# Altium schematic sheet Micro_Grid_Sch.SchDoc
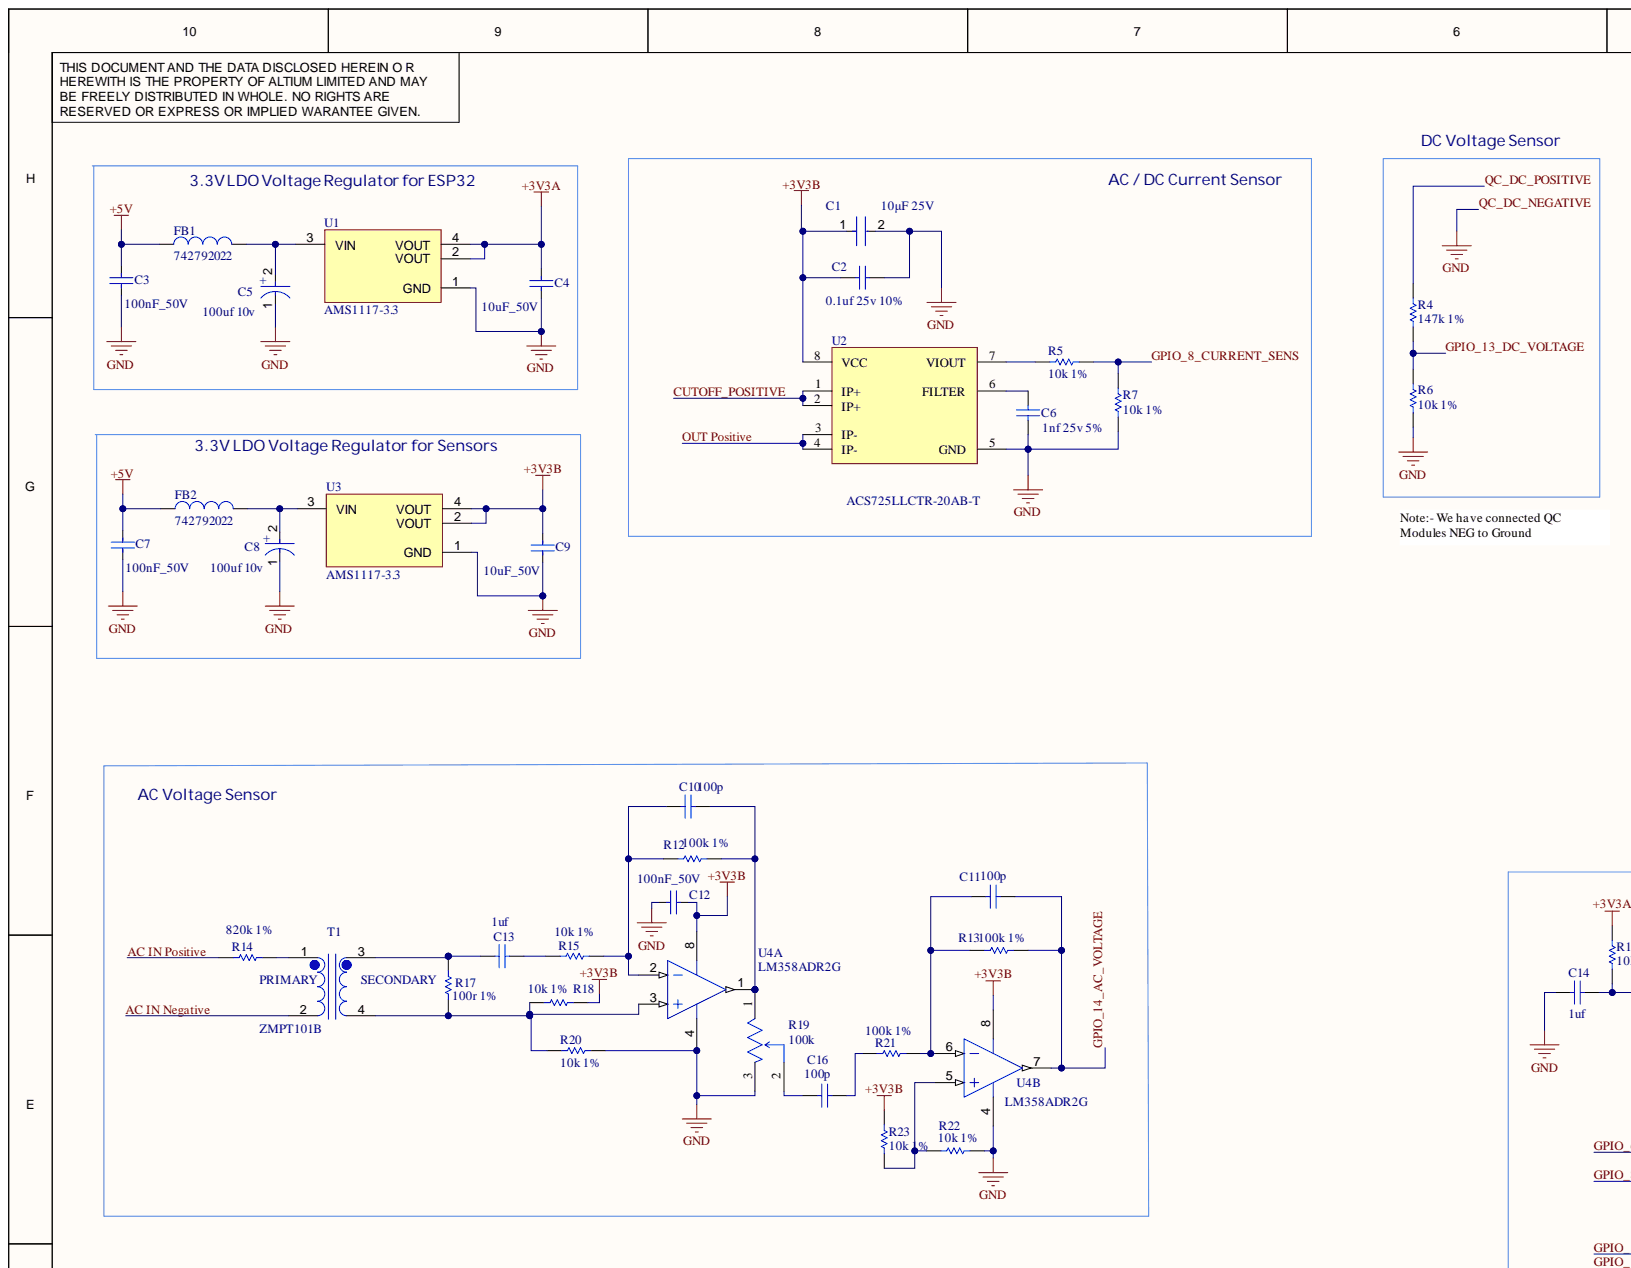
[diagram p0: Micro_Grid_Sch.SchDoc, top left]
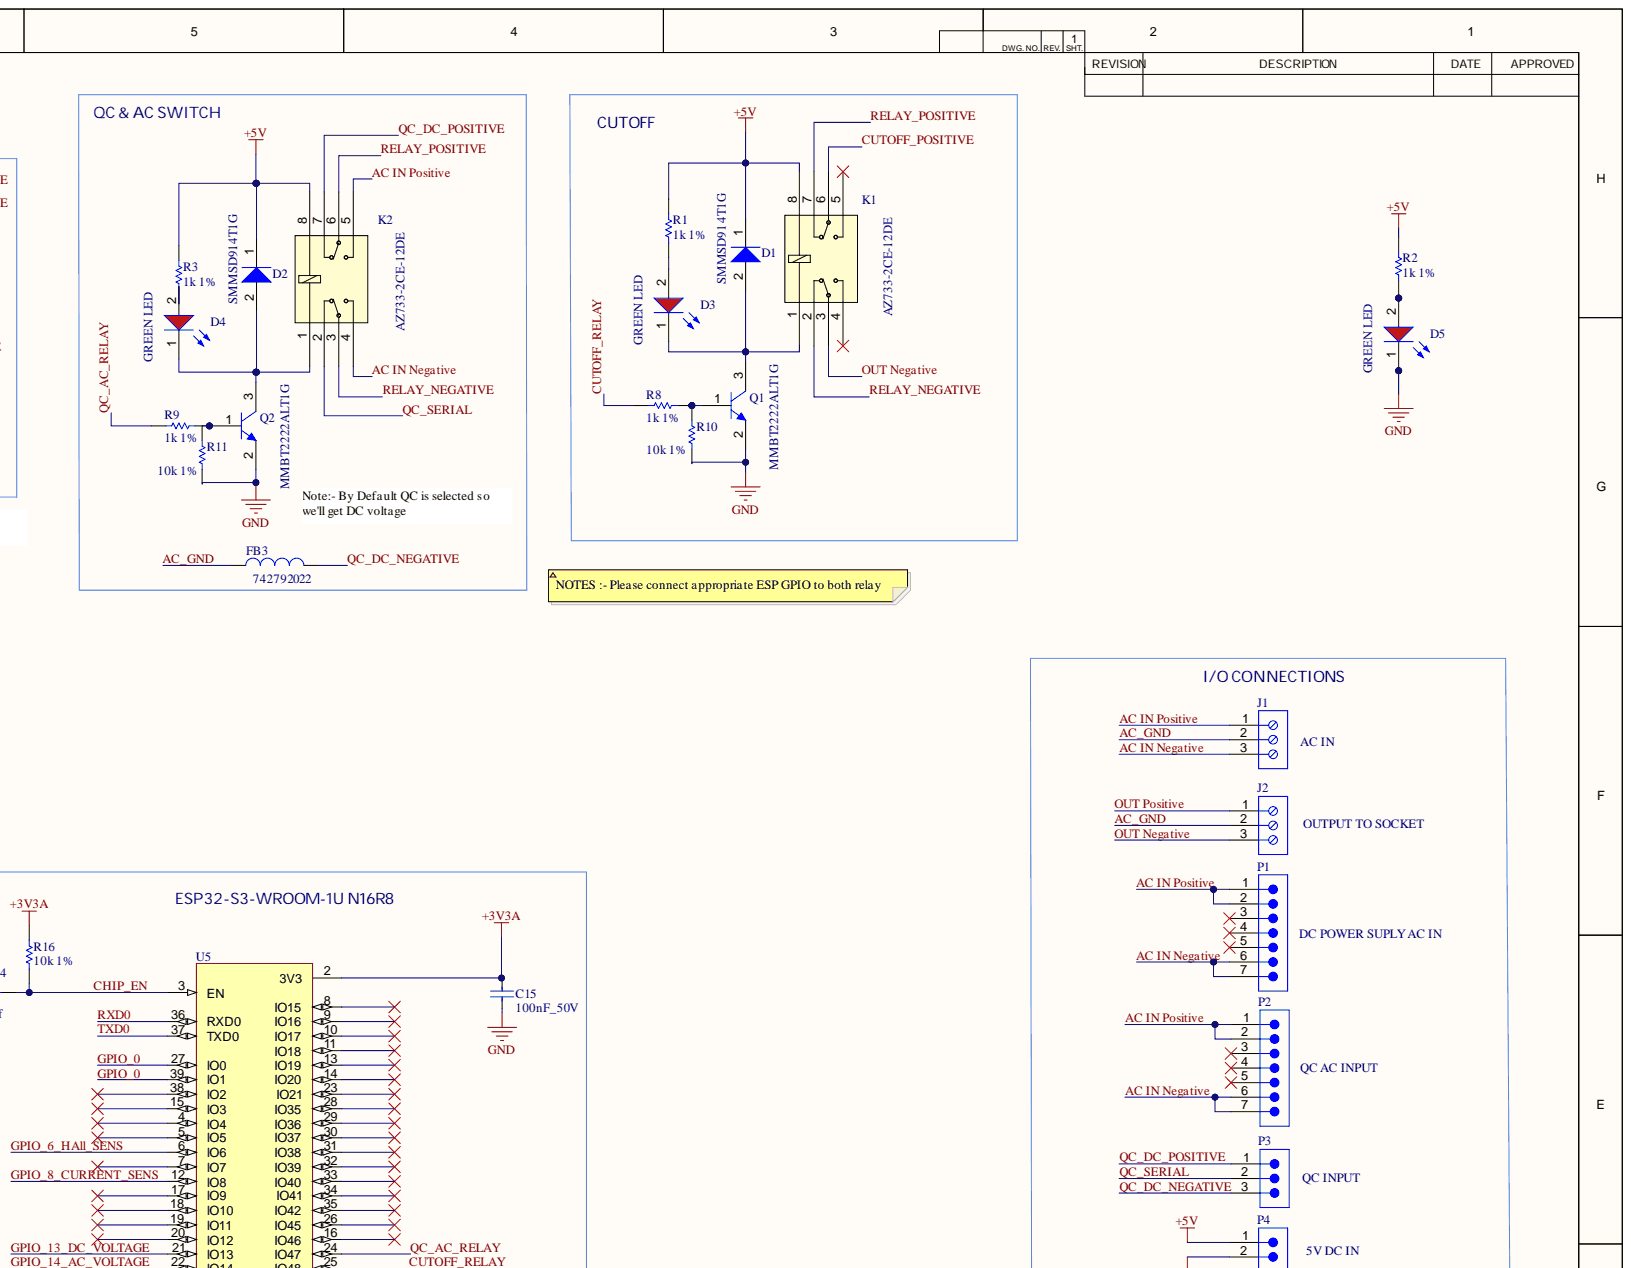
[diagram p1: Micro_Grid_Sch.SchDoc, top right]
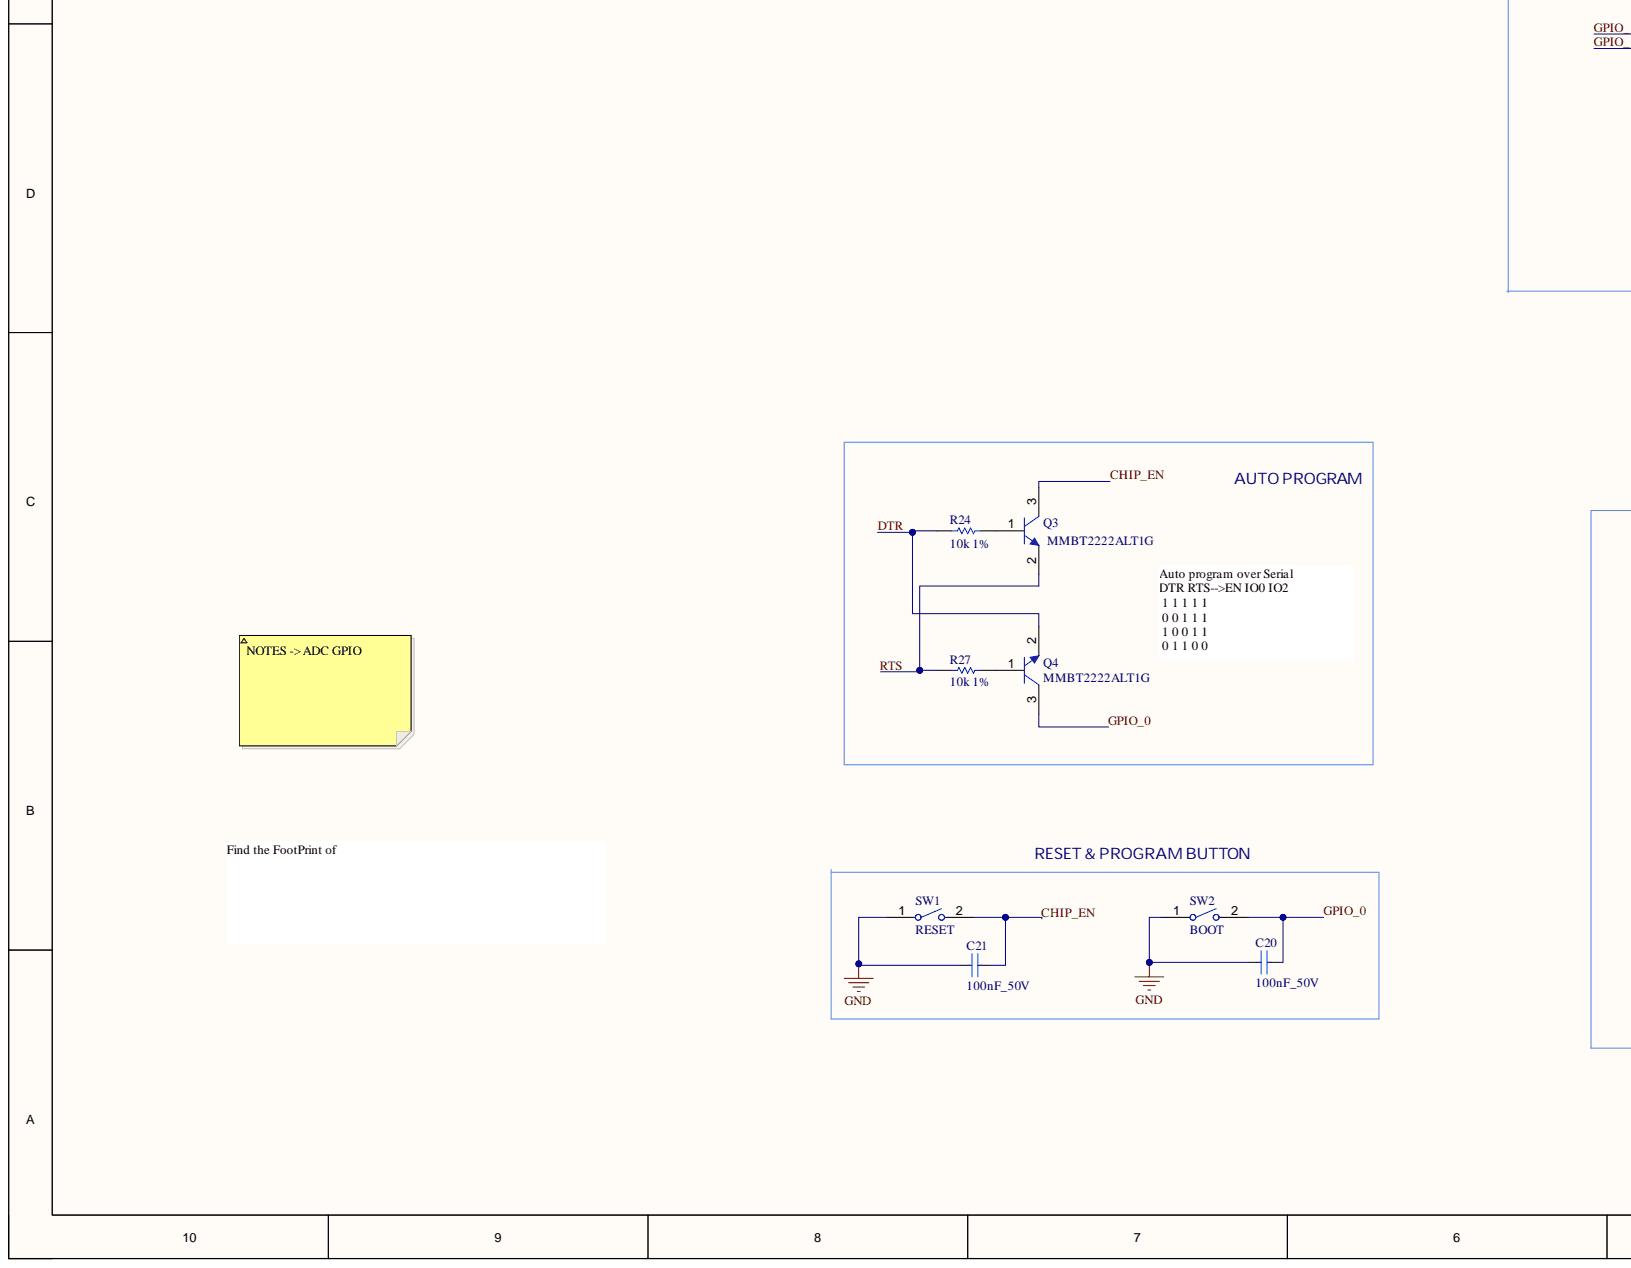
[diagram p2: Micro_Grid_Sch.SchDoc, bottom left]
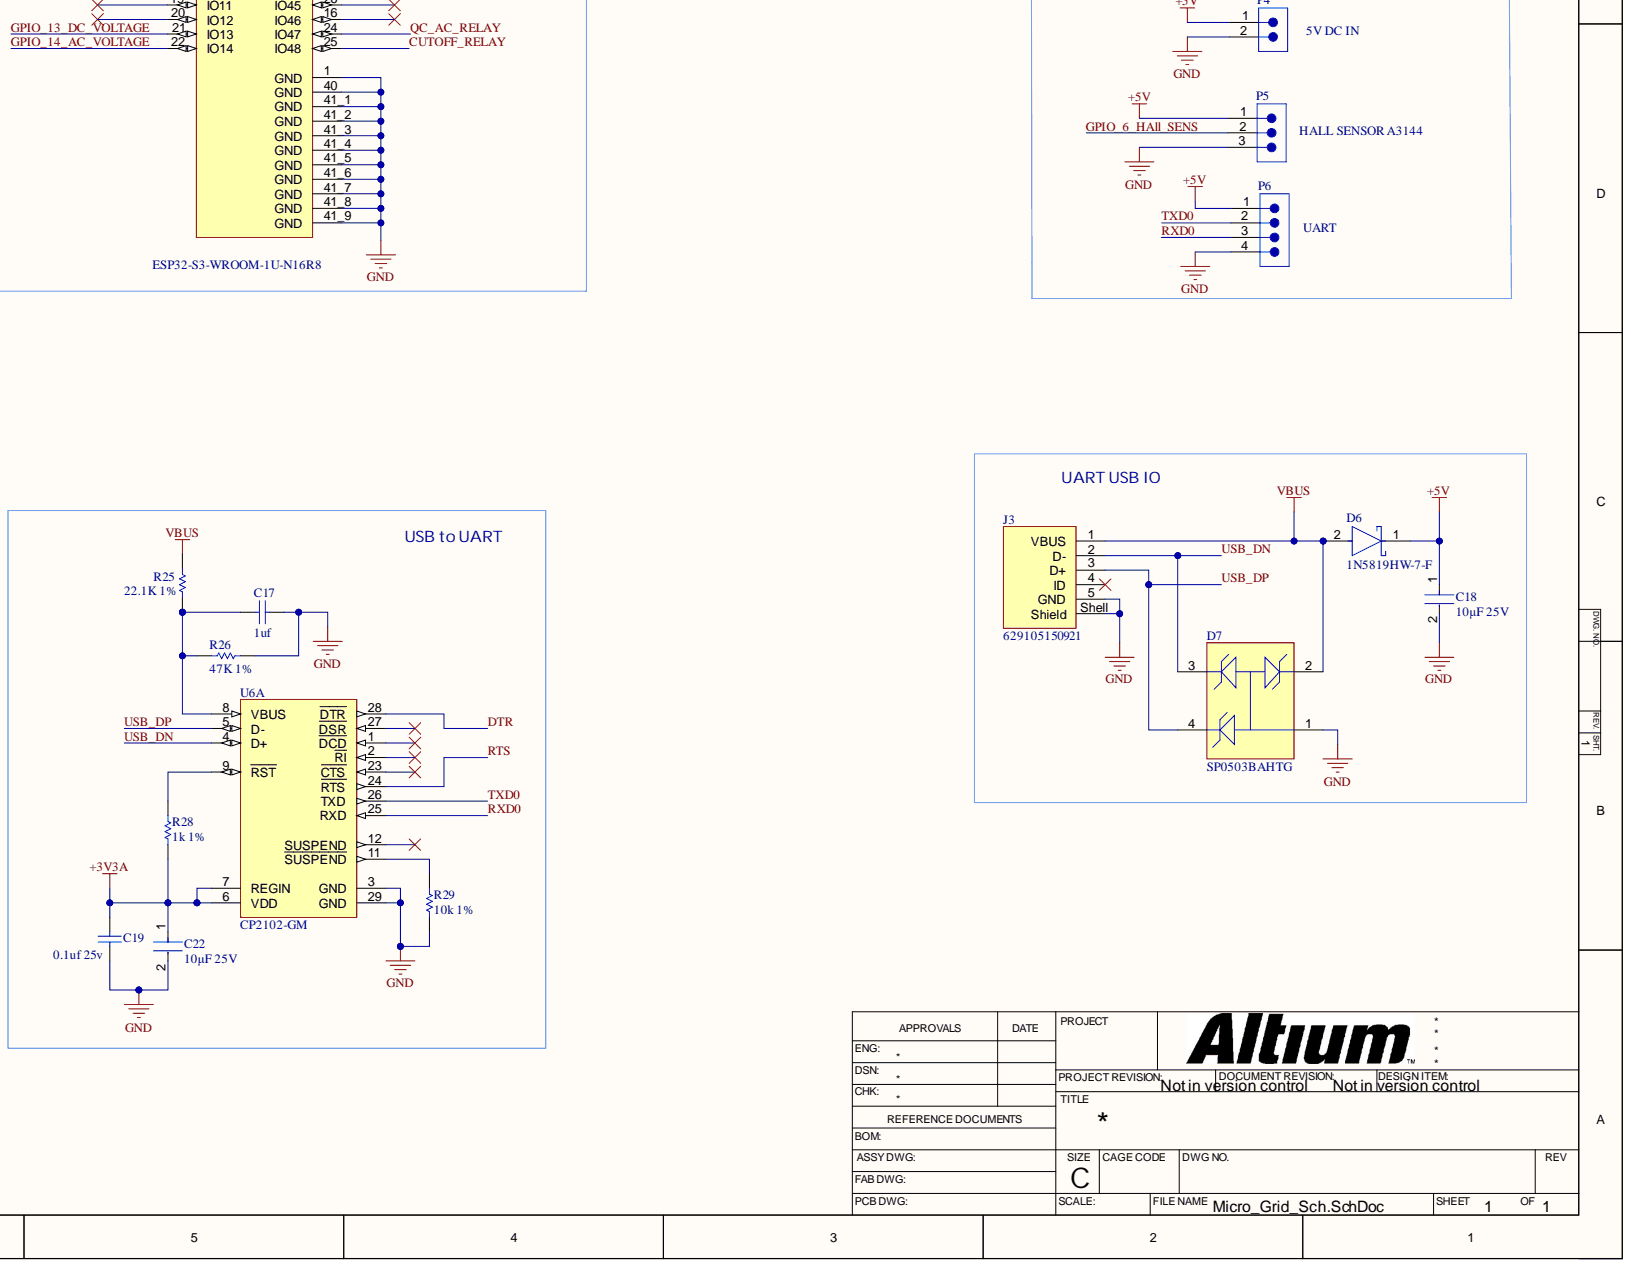
[diagram p3: Micro_Grid_Sch.SchDoc, bottom right]

## Parts
C1  10μF 25V  Voltage: 25V  [FP-C2012-125-0_2-IPC_B]
C2  0.1uf 25v 10%  [CAPC2013X135X50ML15T20]
C3  value 100nF_50V  [C0805]  (hidden comment: C0805C104K5RAC7411)
C4  value 10uF_50V  [C0805]  (hidden comment: C0805C104K5RAC7411)
C5  100uf 10v  [FP-SC-MFG]
C6  1nf 25v 5%  [CAPC2013X145X50NL20T20]
C7  value 100nF_50V  [C0805]  (hidden comment: C0805C104K5RAC7411)
C8  100uf 10v  [FP-SC-MFG]
C9  value 10uF_50V  [C0805]  (hidden comment: C0805C104K5RAC7411)
C10  100p  [CAPC2013X140X50NL20T25]
C11  100p  [CAPC2013X140X50NL20T25]
C12  value 100nF_50V  [C0805]  (hidden comment: C0805C104K5RAC7411)
C13  1uf  [CAPC2013X140X50LL20T25]
C14  1uf  [CAPC2013X140X50LL20T25]
C15  value 100nF_50V  [C0805]  (hidden comment: C0805C104K5RAC7411)
C16  100p  [CAPC2013X140X50NL20T25]
C17  1uf  [CAPC2013X140X50LL20T25]
C18  10μF 25V  Voltage: 25V  [FP-C2012-125-0_2-IPC_B]
C19  0.1uf 25v  [CAPC2013X135X50ML15T20]
C20  value 100nF_50V  [C0805]  (hidden comment: C0805C104K5RAC7411)
C21  value 100nF_50V  [C0805]  (hidden comment: C0805C104K5RAC7411)
C22  10μF 25V  Voltage: 25V  [FP-C2012-125-0_2-IPC_B]
D1  SMMSD914T1G  [ONSC-SOD-123-2-425-04_V]
D2  SMMSD914T1G  [ONSC-SOD-123-2-425-04_V]
D3  GREEN LED  [WL-SMCW_0805_150080xx75000]
D4  GREEN LED  [WL-SMCW_0805_150080xx75000]
D5  GREEN LED  [WL-SMCW_0805_150080xx75000]
D6  1N5819HW-7-F  [FP-SOD123-IPC_A]
D7  SP0503BAHTG  [LFUS-SOT143-4_V]
FB1  742792022  [WE-CBF_0805_L2W1.2H0.9_HC]
FB2  742792022  [WE-CBF_0805_L2W1.2H0.9_HC]
FB3  742792022  [WE-CBF_0805_L2W1.2H0.9_HC]
J1  AC IN  [691214110003]
J2  OUTPUT TO SOCKET  [691214110003]
J3  629105150921  Connector Type: USB  [629105150921]
K1  AZ733-2CE-12DE  [AZ733-2C_AMZ]
K2  AZ733-2CE-12DE  [AZ733-2C_AMZ]
P1  DC POWER SUPLY AC IN  [61300711121]
P2  QC AC INPUT  [61300711121]
P3  QC INPUT  [61300311121]
P4  5V DC IN  [61300211121]
P5  HALL SENSOR A3144  [61300311121]
P6  UART  [61900411121]
Q1  MMBT2222ALT1G  [ONSC-SOT-23-3-318-08_V]
Q2  MMBT2222ALT1G  [ONSC-SOT-23-3-318-08_V]
Q3  MMBT2222ALT1G  [ONSC-SOT-23-3-318-08_V]
Q4  MMBT2222ALT1G  [ONSC-SOT-23-3-318-08_V]
R1  1k 1%  Tolerance: 1%  [RESC2013X60X35ML10T20]
R2  1k 1%  Tolerance: 1%  [RESC2013X60X35ML10T20]
R3  1k 1%  [RESC2013X60X35ML10T20]
R4  147k 1%  [RESC2013X70X40LL20T20]
R5  10k 1%  [RESC2013X50X30NL20T20]
R6  10k 1%  [RESC2013X60X40NL15T20]
R7  10k 1%  [RESC2013X50X30NL20T20]
R8  1k 1%  Tolerance: 1%  [RESC2013X60X35ML10T20]
R9  1k 1%  Tolerance: 1%  [RESC2013X60X35ML10T20]
R10  10k 1%  [RESC2013X60X40NL15T20]
R11  10k 1%  [RESC2013X60X40NL15T20]
R12  100k 1%  [RESC2013X60X30NL20T20]
R13  100k 1%  [RESC2013X60X30NL20T20]
R14  820k 1%  [RESC2013X70X40ML20T20]
R15  10k 1%  [RESC2013X60X40NL15T20]
R16  10k 1%  [RESC2013X60X40NL15T20]
R17  100r 1%  [RESC2013X50X30LL20T20]
R18  10k 1%  [RESC2013X60X40NL15T20]
R19  100k  [FP-TS53YL-MFG]
R20  10k 1%  [RESC2013X60X40NL15T20]
R21  100k 1%  [RESC2013X60X30NL20T20]
R22  10k 1%  [RESC2013X60X40NL15T20]
R23  10k 1%  [RESC2013X60X40NL15T20]
R24  10k 1%  [RESC2013X60X40NL15T20]
R25  22.1K 1%  [RESC2013X70X40NL20T20]
R26  47K 1%  [RESC2013X60X35NL10T20]
R27  10k 1%  [RESC2013X60X40NL15T20]
R28  1k 1%  Tolerance: 1%  [RESC2013X60X35ML10T20]
R29  10k 1%  [RESC2013X60X35NL10T20]
SW1  RESET  [TECO-FSMSM-2_V]
SW2  BOOT  [TECO-FSMSM-2_V]
T1  ZMPT101B  [XFMR_ZMPT101B]
U1  AMS1117-3.3  [SOT-223]
U2  ACS725LLCTR-20AB-T  [FP-LCN-8-IPC_B]
U3  AMS1117-3.3  [SOT-223]
U4  LM358ADR2G  [ONSC-SOIC-8-751-07_V]  (parts A,B)
U5  ESP32-S3-WROOM-1U-N16R8  [XCVR_ESP32-S3-WROOM-1U-N16R8]
U6  CP2102-GM  [SLAB-QFN-28-3125x3125TP_N]  (part A)

## Nets
+3V3A: C4.1 C15.1 C19.1 C22.1 R16.1 R28.2 U1.2(VOUT) U1.4(VOUT) U5.2(3V3) U6.6(VDD) U6.7(REGIN)
+3V3B: C1.1 C2.1 C9.1 C12.2 R18.2 R23.2 U2.8(VCC) U3.2(VOUT) U3.4(VOUT) U4.8
+5V: C3.1 C7.1 C18.1 D1.1 D2.1 D6.1 FB1.1 FB2.1 K1.8 K2.8 P4.1 P5.1 P6.1 R1.1 R2.1 R3.1
VBUS: D6.2 D7.2 J3.1(VBUS) R25.2
AC IN Negative: J1.3 K2.4 P1.6 P1.7 P2.6 P2.7 T1.2
AC IN Positive: J1.1 K2.5 P1.1 P1.2 P2.1 P2.2 R14.1
AC_GND: FB3.1 J1.2 J2.2
CHIP_EN: C14.2 C21.1 Q3.3 R16.2 SW1.2 U5.3(EN)
CUTOFF_POSITIVE: K1.6 U2.1(IP+) U2.2(IP+)
CUTOFF_RELAY: R8.1 U5.25(IO48)
DTR: Q4.2 R24.2 U6.28(DTR)
GPIO_0: C20.1 Q4.3 SW2.2 U5.27(IO0) U5.39(IO1)
GPIO_6_HAll_SENS: P5.2 U5.6(IO6)
GPIO_8_CURRENT_SENS: R5.2 R7.2 U5.12(IO8)
GPIO_13_DC_VOLTAGE: R4.2 R6.1 U5.21(IO13)
GPIO_14_AC_VOLTAGE: C11.2 R13.2 U4.7 U5.22(IO14)
OUT Negative: J2.3 K1.3
OUT Positive: J2.1 U2.3(IP-) U2.4(IP-)
QC_AC_RELAY: R9.1 U5.24(IO47)
QC_DC_NEGATIVE: C1.2 C2.2 C3.2 C4.2 C5.1 C6.2 C7.2 C8.1 C9.2 C12.1 C14.1 C15.2 C17.2 C18.2 C19.2 C20.2 C21.2 C22.2 D5.1 D7.1 FB3.2 J3.5(GND) J3.Shell(Shield) P3.3 P4.2 P5.3 P6.4 Q1.2 Q2.2 R6.2 R7.1 R10.1 R11.1 R19.3 R20.2 R22.2 R26.2 R29.1 SW1.1 SW2.1 U1.1(GND) U2.5(GND) U3.1(GND) U4.4 U5.1(GND) U5.40(GND) U5.41_1(GND) U5.41_2(GND) U5.41_3(GND) U5.41_4(GND) U5.41_5(GND) U5.41_6(GND) U5.41_7(GND) U5.41_8(GND) U5.41_9(GND) U6.3(GND) U6.29(GND)
QC_DC_POSITIVE: K2.7 P3.1 R4.1
QC_SERIAL: K2.2 P3.2
RELAY_NEGATIVE: K1.2 K2.3
RELAY_POSITIVE: K1.7 K2.6
RTS: Q3.2 R27.2 U6.24(RTS)
RXD0: P6.3 U5.36(RXD0) U6.25(RXD)
TXD0: P6.2 U5.37(TXD0) U6.26(TXD)
USB_DN: D7.3 J3.2(D-) U6.4(D+)
USB_DP: D7.4 J3.3(D+) U6.5(D-)
NetC5_2: C5.2 FB1.2 U1.3(VIN)
NetC6_1: C6.1 U2.6(FILTER)
NetC8_2: C8.2 FB2.2 U3.3(VIN)
NetC10_1: C10.1 R12.1 R15.2 U4.2
NetC10_2: C10.2 R12.2 R19.1 U4.1
NetC11_1: C11.1 R13.1 R21.2 U4.6
NetC13_1: C13.1 R17.2 T1.3
NetC13_2: C13.2 R15.1
NetC16_1: C16.1 R19.2
NetC16_2: C16.2 R21.1
NetC17_1: C17.1 R25.1 R26.1 U6.8(VBUS)
NetD1_2: D1.2 D3.1 K1.1 Q1.3
NetD2_2: D2.2 D4.1 K2.1 Q2.3
NetD3_2: D3.2 R1.2
NetD4_2: D4.2 R3.2
NetD5_2: D5.2 R2.2
NetQ1_1: Q1.1 R8.2 R10.2
NetQ2_1: Q2.1 R9.2 R11.2
NetQ3_1: Q3.1 R24.1
NetQ4_1: Q4.1 R27.1
NetR5_1: R5.1 U2.7(VIOUT)
NetR14_2: R14.2 T1.1
NetR17_1: R17.1 R18.1 R20.1 T1.4 U4.3
NetR22_1: R22.1 R23.1 U4.5
NetR28_1: R28.1 U6.9(RST)
NetR29_2: R29.2 U6.11(SUSPEND)

Not connected: J3 4(ID); K1 4 5; P1 3 4 5; P2 3 4 5; U5 4(IO4) 5(IO5) 7(IO7) 8(IO15) 9(IO16) 10(IO17) 11(IO18) 13(IO19) 14(IO20) 15(IO3) 16(IO46) 17(IO9) 18(IO10) 19(IO11) 20(IO12) 23(IO21) 26(IO45) 28(IO35) 29(IO36) 30(IO37) 31(IO38) 32(IO39) 33(IO40) 34(IO41) 35(IO42) 38(IO2); U6 1(DCD) 2(RI) 12(SUSPEND) 23(CTS) 27(DSR)

## Library data of the parts
C1 C18 C22 = CMP-08246-002675-1: Multilayer Ceramic Capacitors 10μF ±10% 25V X5R SMD 0805; Capacitance: 10uF; Case Code (Imperial): 0805; Case Code (Metric): 2012; Case/Package: 0805; Component Kind: Standard; Depth: 1.25mm; Dielectric: X5R; Dielectric Material: Ceramic; Height: 1.25mm; Lead Free: Lead Free; Length: 2mm; Library Name: Altium Content Vault; Max Operating Temperature: 85°C; Min Operating Temperature: -55°C; Mount: Surface Mount; Package Quantity: 1; Packaging: Tape and Reel; REACH SVHC: No SVHC; Resistor Type: Ceramic; Series: C; Termination: SMD/SMT; Thickness: 0.057inch; Tolerance: 10%; Voltage Rating: 25V; Voltage Rating (DC): 25V; Weight: 0.000194oz; Width: 0.049inch
C2 C19 = CMP-2007-03442-1: VJ0805Y104KXXCW1BC; Manufacturer: Vitramon; Component Kind: Standard; Library Name: Altium Content Vault; Part Number: VJ0805Y104KXXCW1BC
C3 C4 C7 C9 C12 C15 C20 C21 = CAPACITOR: C0805 0.10 μF X7R 30ppm/°C 10.00% 50 V; Manufacturer: KEMET; Capacitance: 100nF; Material: Ceramic; Tolerance: 0.1; Voltage Rating: 50 V; Automotive: No; Category: Cap; Component Kind: Standard; ComponentLink2Description: Datasheet; ComponentLink2URL: https://content.kemet.com/datasheets/KEM_C1003_C0G_SMD.pdf; Device Class L1: Passive Components; Device Class L2: Capacitors; Device Class L3: Ceramic Capacitors; Height: 0.88mm; Ipc Land Pattern Name: CAPC200125X78; Lead Free: Yes; Library Name: CustomLib-Package.IntLib; Manufacturer Part Number: C0805C104K5RAC7411; Octopart: https://octopart.com/c0805c104k5rac7411-kemet-18508045; Package: C0805C-DN; RoHS: TRUE; Temperature: -55°C to +125°C; Temperature Characteristic: X7R; Temperature Coefficient: 30ppm/°C; Temperature Range High: +125°C; Temperature Range Low: -55°C
C5 C8 = CMP-05427-000047-1: EEE-1AA101WR, CAP ALUM 100UF 20% 10V SMD; Life (Hours): 1000Hour; Ripple Current: 50mA; Capacitance: 100uF; Case/Package: Radial; Component Kind: Standard; Construction: Cylindrical; Contact Plating: Tin; Diameter: 5mm; Height: 5.4mm; Height - Seated (Max): 0.213inch; Lead Free: Lead Free; Length: 5.5mm; Library Name: Altium Content Vault; Max Operating Temperature: 85°C; Min Operating Temperature: -40°C; Mount: Surface Mount; Package Quantity: 1; Packaging: Tape and Reel; Radiation Hardening: No; Ripple Current (AC): 50mA; RoHS Compliant: Yes; Series: S; Termination: SMD/SMT; Tolerance: 20%; Voltage Rating: 10V; Voltage Rating (DC): 10V
C6 = CMP-2007-01395-1: VJ0805Y102JXXPW1BC; Manufacturer: Vitramon; Component Kind: Standard; Library Name: Altium Content Vault; Part Number: VJ0805Y102JXXPW1BC
C10 C11 C16 = CMP-2007-03324-1: C0805C101KCGACTU; Manufacturer: Kemet; Component Kind: Standard; Library Name: Altium Content Vault; Part Number: C0805C101KCGACTU
C13 C14 C17 = CMP-2007-03234-1: C0805C105K3RACTU; Manufacturer: Kemet; Component Kind: Standard; Library Name: Altium Content Vault; Part Number: C0805C105K3RACTU
D1 D2 = CMP-1054-00051-1: SMMSD914T1G, Switching Diode, 2-Pin SOD-123, Pb-Free, Tape and Reel; Manufacturer: On Semiconductor; Configuration: Single; IF Max (A): 0.2; trr Max (ns): 4; Component Kind: Standard; ComponentLink1Description: Manufacturer URL; ComponentLink1URL: http://www.onsemi.com/; ComponentLink2Description: Datasheet; ComponentLink2URL: http://www.onsemi.com/pub_link/Collateral/MMSD914T1-D.PDF; ComponentLink3Description: Package Specification; ComponentLink3URL: http://www.onsemi.com/pub_link/Collateral/425-04.PDF; DatasheetVersion: Rev. 11; Library Name: Altium Content Vault; Mounting Technology: Surface Mount; PackageDescription: 2-Pin SOD, Body 1.6 x 2.69 mm; PackageReference: SOD-123-2-425-04; PackageVersion: Rev. G, 10/2009; Packing: Tape and Reel; PartNumber: SMMSD914T1G; RoHS: TRUE
D3-D5 = CMP-1426-00010-4: Led, Red, 630 Nm, 1.9 V, 30 Ma, 60 Mcd Rohs Compliant: Yes; Dominant Wavelength: 630nm; Forward Current: 100mA; Forward Voltage: 1.9V; Lens Style: Clear, Rectangular; Luminous Intensity: 60mcd; Max Junction Temperature (Tj): 95°C; Max Reverse Voltage (DC): 5V; Peak Wavelength: 645nm; Power Dissipation: 72mW; Test Current: 20mA; Viewing Angle: 140°; Case Code (Imperial): 0805; Case Code (Metric): 2012; Case/Package: 0805; Color: Red; Component Kind: Standard; Height: 700µm; Length: 2mm; Library Name: Altium Content Vault; Max Operating Temperature: 85°C; Min Operating Temperature: -40°C; Mount: Surface Mount; Packaging: Tape and Reel; REACH SVHC: Unknown; Reverse Voltage: 5V; RoHS: Compliant; Wavelength: 630nm; Width: 1.25mm
D6 = CMP-0303-00003-3: DIODE SCHOTTKY 40V 1A SOD123; Capacitance: 110pF; Element Configuration: Single; Forward Voltage: 450mV; Max Forward Surge Current (Ifsm): 25A; Max Power Dissipation: 450mW; Peak Reverse Current: 50uA; Polarity: Standard; Average Rectified Current: 1A; Case/Package: SOD-123-2; Component Kind: Standard; Contact Plating: Tin; Current Rating: 1A; Forward Current: 1A; Height: 1.25mm; Lead Free: Lead Free; Length: 2.85mm; Library Name: Altium Content Vault; Max Operating Temperature: 125°C; Max Repetitive Reverse Voltage (Vrrm): 40V; Max Reverse Voltage (DC): 40V; Min Operating Temperature: -65°C; Number of Pins: 2; Output Current: 1A; Package Quantity: 3000; Packaging: Tape and Reel; Peak Non-Repetitive Surge Current: 25A; Radiation Hardening: No; REACH SVHC: No SVHC; Termination: SMD/SMT; Voltage Rating (DC): 40V; Weight: 0.000353oz; Width: 1.7mm
D7 = CMP-2000-05191-1: TVS Diode Array, 30 pF, 30 KV, -40 to 85 degC, 4-Pin SOT143, RoHS, Tape and Reel; Manufacturer: Littelfuse; Capacitance Value: 30pF; Clamping Voltage: 8V; Configuration: Triple; Direction Type: Uni-Directional; Leakage Current: 0.1uA; Number of Elements: 3; Product Depth (mm): 1.4mm; Product Height (mm): 1.05mm; Product Length (mm): 3.04mm; Rad Hardened: No; Reverse Stand-off Voltage: 5.5(Min)V; Working Voltage: 5.5V; Working Voltage (Max): 5.5V(Min); Clamping Voltage (Max): 8V; Component Kind: Standard; Datasheet URL: https://datasheet.ciiva.com/15143/getdatasheetpartid-116020-15143550.pdf; Datasheet Version: Dec-13; Leakage Current (Max): 0.1uA; Library Name: Altium Content Vault; Manufacturer URL: http://www.littelfuse.com/; Mounting: SurfaceMount; Mounting Style: SurfaceMount; Operating Temp Range: -40Cto85C; Operating Temperature Classification: Industrial; Operating Temperature Max Deg. C: 85C; Operating Temperature Min Deg. C: -40C; Package / Case: SOT-143; Package Type: SOT-143; Packaging: TapeandReel; Pin Count: 3+Tab; Polarity: Uni-Directional; Suppressor Type: TVS
FB1-FB3 = CMP-0220-00029-2: NexarPartId: 7861696; Core Material: Ferrite; Current Rating: 2A; DC Resistance (DCR): 50mΩ; Impedance: 220Ω; Inductance: 5.6H; Number of Lines: 1; Test Frequency: 100MHz; Tolerance: 25%; Case Code (Imperial): 0805; Case Code (Metric): 2012; Case/Package: SMD/SMT; Component Kind: Standard; Depth: 1.2mm; Height: 900µm; Lead Free: Lead Free; Length: 2mm; Library Name: Altium Content Vault; Material: Ferrite; Max Current Rating: 2A; Max DC Current: 2A; Max Operating Temperature: 125°C; Min Operating Temperature: -55°C; Mount: Surface Mount; Packaging: Digi-Reel®; REACH SVHC: Unknown; Resistance: 50mΩ; RoHS: Compliant; Termination: SMD/SMT; Weight: 10.999615mg; Width: 1.2mm
J1 J2 = CMP-1502-02466-2: NexarPartId: 18818289; Current: 10A; Number of Contacts: 3; Number of Levels: 1; Pitch: 3.5052mm; Plating: Brass, Steel; Stripping Length: -6mm; Voltage: 300V; Wire Gauge (Max): 24AWG; Wire Gauge (Min): 16AWG; Color: Blue, Green; Component Kind: Standard; Contact Material: Brass; Contact Plating: Brass, Tin; Current Rating: 10A; Height: 12.2mm; Housing Material: Nylon; Lead Pitch: 3.5mm; Length: 7.4mm; Library Name: Altium Content Vault; Max Operating Temperature: 105°C; Min Operating Temperature: -40°C; Mount: Through Hole; Number of Pins: 3; Number of Positions Per Level: 3; Packaging: Box; REACH SVHC: Unknown; RoHS: Compliant; Termination: Screw; Voltage Rating: 300V; Width: 10.9mm; Wire/Cable Gauge: 24AWG
J3 = CMP-1502-03249-5: NexarPartId: 52645114; Contact Resistance: 30mΩ; Current Rating: 1.8A; Gender: Receptacle; Insulation Resistance: 1GΩ; Number of Contacts: 5; Operating Supply Voltage: 30V; USB Standard: USB 2.0; Component Kind: Standard; Contact Material: Gold; Contact Plating: Gold; Features: Shielded; Height: 2.82mm; Library Name: Altium Content Vault; Max Operating Temperature: 85°C; Min Operating Temperature: -40°C; Mount: Horizontal, Surface Mount; Number of Pins: 5; Orientation: Horizontal; Packaging: Tape and Reel; REACH SVHC: Unknown; RoHS: Compliant; Termination: SMD/SMT; Voltage Rating: 30V
K1 K2 = AZ733-2CE-12DE: No Description Available; Mfr_Name: American Zettler; Component Kind: Standard; Library Name: AZ733-2CE-12DE.SchLib; RefDes: RefDes; Type: Power Relays
P1 P2 = CMP-1502-01074-3: NexarPartId: 32855531; Connector Type: Male Pin; Contact Resistance: 20mΩ; Current Rating: 3A; Gender: Male; Number of Contacts: 7; Operating Supply Voltage: 250V; Pitch: 2.54mm; Color: Black; Component Kind: Standard; Contact Material: Copper; Contact Mating Length: 5.9944mm; Contact Plating: Gold; Lead Pitch: 2.54mm; Library Name: Altium Content Vault; Mount: Through Hole; Number of Pins: 7; Number of Positions: 7; Number of Rows: 1; Orientation: Vertical; Packaging: Bulk; REACH SVHC: Unknown; RoHS: Compliant; Termination: Solder
P3 P5 = CMP-1502-01062-3: NexarPartId: 32855521; Connector Type: Male Pin; Contact Resistance: 20mΩ; Current Rating: 3A; Gender: Male; Number of Contacts: 3; Operating Supply Voltage: 250V; Pitch: 2.54mm; Color: Black; Component Kind: Standard; Contact Material: Copper; Contact Mating Length: 5.9944mm; Contact Plating: Gold; Height: 8.94mm; Lead Free: Lead Free; Lead Pitch: 2.54mm; Library Name: Altium Content Vault; Max Operating Temperature: 105°C; Min Operating Temperature: -40°C; Mount: Through Hole; Number of Pins: 3; Number of Positions: 3; Number of Rows: 1; Orientation: Vertical; Packaging: Bulk; REACH SVHC: Unknown; RoHS: Compliant; Termination: Solder; Voltage Rating: 250V
P4 = CMP-1712-00002-6: NexarPartId: 20081794; Connector Type: Male Pin; Contact Resistance: 20mΩ; Current Rating: 3A; Gender: Male; Number of Contacts: 2; Operating Supply Voltage: 250V; Pitch: 2.54mm; Color: Black; Component Kind: Standard; Contact Gender: Male; Contact Material: Copper; Contact Mating Length: 5.9944mm; Contact Plating: Gold; Height: 8.94mm; Housing Material: PBT; Lead Free: Lead Free; Lead Pitch: 2.54mm; Library Name: Altium Content Vault; Max Operating Temperature: 125°C; Min Operating Temperature: -40°C; Mount: Through Hole; Number of Pins: 2; Number of Positions: 2; Number of Rows: 1; Orientation: Vertical; Packaging: Bulk; REACH SVHC: No SVHC; RoHS: Compliant; Termination: Through Hole; Voltage Rating: 250V
P6 = CMP-1502-00586-2: 61900411121; NexarPartId: 18818226; Connector Type: Male Pin; Contact Resistance: 20mΩ; Current Rating: 3A; Gender: Male; Insulation: Insulated; Insulation Resistance: 1GΩ; Number of Contacts: 4; Operating Supply Voltage: 250V; Pitch: 2.54mm; Color: Beige; Component Kind: Standard; Contact Gender: Male; Contact Material: Copper; Contact Mating Length: 7.493mm; Contact Plating: Tin; Fastening Type: Friction; Flammability Rating: UL94 V-0; Height: 3.3mm; Housing Material: Nylon; Lead Free: Lead Free; Lead Pitch: 2.54mm; Length: 10.16mm; Library Name: Altium Content Vault; Max Operating Temperature: 105°C; Min Operating Temperature: -40°C; Mount: Through Hole; Number of Pins: 4; Number of Positions: 4; Number of Rows: 1; Orientation: Vertical; Packaging: Bulk; REACH SVHC: Unknown; RoHS: Compliant; Termination: Through Hole; Voltage Rating: 250V; Width: 10.2mm
Q1-Q4 = CMP-1048-00538-1: General Purpose Transistor, NPN Silicon, 3-Pin SOT-23, Pb-Free, Tape and Reel; Manufacturer: On Semiconductor; fT Min (MHz): 300; IC Continuous (A): 0.6; Component Kind: Standard; ComponentLink1Description: Manufacturer URL; ComponentLink1URL: http://www.onsemi.com/; ComponentLink2Description: Datasheet; ComponentLink2URL: http://www.onsemi.cn/pub_link/Collateral/MMBT2222LT1-D.PDF; ComponentLink3Description: Package Specification; ComponentLink3URL: http://www.onsemi.cn/pub_link/Collateral/318-08.PDF; DatasheetVersion: Rev. 10; Library Name: Altium Content Vault; Mounting Technology: Surface Mount; PackageDescription: 3-Pin Small Outline Transistor, Body 2.92 x 1.3 mm, Pitch 0.95 mm; PackageReference: SOT-23-3-318-08; PackageVersion: Rev. AP, 11/2009; Packing: Tape and Reel; PartNumber: MMBT2222ALT1G; Polarity: NPN; RoHS: TRUE
R1-R3 R8 R9 = CMP-2100-03671-1: RC0805FR-071KL Chip Resistor, 1 KOhm, +/- 1%, 125 mW, -55 to 155 degC, 0805 (2012 Metric), RoHS, Tape and Reel; Manufacturer: Yageo; Max Power Dissipation: 125mW; Resistance: 1KOhm; Temperature Coefficient: 100ppm/degreesC; Voltage Rating: 150V; Case Code (Imperial): 0805; Case Code (Metric): 2012; Case/Package: 0805; Component Kind: Standard; Composition: ThickFilm; Datasheet URL: http://www.yageo.com/NewPortal/yageodocoutput?fileName=/pdf/R-Chip/PYu-RC_Group_51_RoHS_L_5.pdf; Datasheet Version: Rev. 5, 10/2016; Depth: 1.25mm; Height: 0.024inch; Lead Free: LeadFree; Length: 0.079inch; Library Name: Altium Content Vault; Manufacturer URL: http://www.yageo.com/; Max Operating Temperature: 155degC; Military Standard: Not; Min Operating Temperature: -55degC; Number of Pins: 2; Number of Terminations: 2; Package Description: 2-Pin Surface Mounted Device, Body 2 x 1.25 mm; Package Quantity: 1; Package Reference: 0805; Packaging: TapeandReel; Power Rating: 125mW; REACH SVHC: Unknown; RoHSCompliant: true; Termination: SMD/SMT; Voltage Rating (DC): 150V; Width: 0.049inch
  R3: Tolerance: 1%
R4 = CMP-2001-04436-1: ERJ-6ENF1473V; Manufacturer: Panasonic; Component Kind: Standard; Library Name: Altium Content Vault; Part Number: ERJ-6ENF1473V
R5 R7 = CMP-2001-04915-1: Manufacturer: Vishay Dale; Part Number: CRCW080510R0FKEA; Component Kind: Standard; Library Name: Altium Content Vault
R6 R10 R11 R15 R16 R18 R20 R22-R24 R27 = CMP-2001-04472-1: CRCW080510K0FKEAHP; Manufacturer: Vishay Dale; Component Kind: Standard; Library Name: Altium Content Vault; Part Number: CRCW080510K0FKEAHP
R12 R13 R21 = CMP-2001-01994-1: RK73H2ATTD1003F; Manufacturer: KOA Speer; Component Kind: Standard; Library Name: Altium Content Vault; Part Number: RK73H2ATTD1003F
R14 = CMP-2001-00574-1: ERJ6ENF8203V; Manufacturer: Panasonic; Component Kind: Standard; Library Name: Altium Content Vault; Part Number: ERJ6ENF8203V
R17 = CMP-2001-04901-1: CRCW0805100RFKEA; Manufacturer: Vishay Dale; Component Kind: Standard; Library Name: Altium Content Vault; Part Number: CRCW0805100RFKEA
R19 = CMP-00030-39740690-1: TS53YL104MR10 Resistor Trimmer, Cermet, 100 kOhm, ± 20%, 250 mW, 1 Turn, Single Turn, Surface Mount; Max Power Dissipation: 250mW; Number of Turns: 1; Resistance: 100kΩ; Temperature Coefficient: 100ppm/°C; Voltage Rating: 158V; Voltage Rating (AC): 200V; Component Kind: Standard; Composition: Cermet; Depth: 6.4mm; Height: 2.6924mm; Lead Free: Lead Free; Length: 5mm; Library Name: Altium Content Vault; Max Operating Temperature: 125°C; Military Standard: Not; Min Operating Temperature: -55°C; Mount: Surface Mount; NexarPartId: 39740690; Packaging: Cut Tape; Power Rating: 250mW; Radiation Hardening: No; REACH SVHC: Unknown; RoHS: Compliant; Schedule B: 8533400080, 8533400080; Taper: Linear; Termination: Gull Wing; Tolerance: 20%; Voltage Rating (DC): 200V; Width: 5.0038mm
R25 = CMP-2001-00049-1: ERJ-6ENF2212V; Manufacturer: Panasonic; Component Kind: Standard; Library Name: Altium Content Vault; Part Number: ERJ-6ENF2212V
R26 = CMP-1659-00011-4: RC0805FR-0747KL, Chip Resistor, 47 KOhm, +/- 1%, 0.125 W, -55 to 155 degC, 0805 (2012 Metric), RoHS, Tape and Reel; Manufacturer: Yageo; Voltage Rating: 150 V; Component Kind: Standard; ComponentLink1Description: Manufacturer URL; ComponentLink1URL: http://www.yageo.com/; ComponentLink2Description: Datasheet; ComponentLink2URL: http://www.yageo.com/documents/recent/PYu-RC_Group_51_RoHS_L_04.pdf; DatasheetVersion: Rev. 4, 02/2016; Height: 0.5 mm; Length: 2 mm; Library Name: Altium Content Vault; Max Operating Temperature: 155 degC; Min Operating Temperature: -55 degC; Mount: Surface Mount; Mounting Technology: Surface Mount; Package Quantity: 200; PackageDescription: 2-Pin Surface Mounted Device, Body 2 x 1.25 mm; PackageReference: 0805; Packaging: Tape and Reel; Pins: 2; REACH SVHC: No SVHC; RoHSCompliant: true
R28 = CMP-2100-03671-1: RC0805FR-071KL, Chip Resistor, 1 KOhm, +/- 1%, 125 mW, -55 to 155 degC, 0805 (2012 Metric), RoHS, Tape and Reel; Manufacturer: Yageo; Max Power Dissipation: 125mW; Resistance: 1KOhm; Temperature Coefficient: 100ppm/degreesC; Voltage Rating: 150V; Case Code (Imperial): 0805; Case Code (Metric): 2012; Case/Package: 0805; Component Kind: Standard; Composition: ThickFilm; Datasheet URL: http://www.yageo.com/NewPortal/yageodocoutput?fileName=/pdf/R-Chip/PYu-RC_Group_51_RoHS_L_5.pdf; Datasheet Version: Rev. 5, 10/2016; Depth: 1.25mm; Height: 0.024inch; Lead Free: LeadFree; Length: 0.079inch; Library Name: Altium Content Vault; Manufacturer URL: http://www.yageo.com/; Max Operating Temperature: 155degC; Military Standard: Not; Min Operating Temperature: -55degC; Number of Pins: 2; Number of Terminations: 2; Package Description: 2-Pin Surface Mounted Device, Body 2 x 1.25 mm; Package Quantity: 1; Package Reference: 0805; Packaging: TapeandReel; Power Rating: 125mW; REACH SVHC: Unknown; RoHSCompliant: true; Termination: SMD/SMT; Voltage Rating (DC): 150V; Width: 0.049inch
R29 = CMP-1659-00013-4: RC0805FR-0710KL, Chip Resistor, 10 KOhm, +/- 1%, 0.125 W, -55 to 155 degC, 0805 (2012 Metric), RoHS, Tape and Reel; Manufacturer: Yageo; Voltage Rating: 150 V; Component Kind: Standard; ComponentLink1Description: Manufacturer URL; ComponentLink1URL: http://www.yageo.com/; ComponentLink2Description: Datasheet; ComponentLink2URL: http://www.yageo.com/documents/recent/PYu-RC_Group_51_RoHS_L_04.pdf; DatasheetVersion: Rev. 4, 02/2016; Height: 0.5 mm; Length: 2 mm; Library Name: Altium Content Vault; Max Operating Temperature: 155 degC; Min Operating Temperature: -55 degC; Mount: Surface Mount; Mounting Technology: Surface Mount; Package Quantity: 5000; PackageDescription: 2-Pin Surface Mounted Device, Body 2 x 1.25 mm; PackageReference: 0805; Packaging: Tape and Reel; Pins: 2; REACH SVHC: No SVHC; RoHSCompliant: true
SW1 SW2 = CMP-2000-07511-1: FSMSM Push Button Switch, 50 mA, -35 to 85 degC, 2-Pin SMD, RoHS, Bulk; Manufacturer: TE Connectivity; Actuator Length: 1.07mm; Actuator Material: Polyamide; Case\/Package: SMD/SMT; Contact Current Rating: 50mA; Contact Resistance: 100mOhm; Electrical Life: 20000Cycles; Illumination Type: Illuminated; Max Voltage Rating (DC): 12V; Operating Force: 180gf; Switch Travel: 0.25mm; Throw Configuration: SPST; Voltage Rating (DC): 24V; Component Kind: Standard; Contact Material: Brass; Contact Plating: Silver; Current Rating: 50mA; Datasheet URL: http://www.te.com/commerce/DocumentDelivery/DDEController?Action=showdoc&DocId=Customer+Drawing%7F1437566-3%7FC2%7Fpdf%7FEnglish%7FENG_CD_1437566-3_C2_1437566_3_1_c.dwg.pdf%7F1437566-3; Datasheet Version: Rev. C2, 08/2014; ELV: Compliant; Lead Free: LeadFree; Library Name: Altium Content Vault; Manufacturer URL: http://www.te.com/; Max Operating Temperature: 85degC; Mechanical Life: 20000Cycles; Min Operating Temperature: -35degC; Mount: SurfaceMount; Mounting Technology: SurfaceMount; Orientation: Straight; Package Description: 2-Pin Surface Mount Device, Body 6 x 3.5 mm, Pitch 9.19 mm; Package Quantity: 1000; Package Reference: FSMSM; Packaging: LoosePiece; REACH SVHC: Unknown; RoHSCompliant: true; Termination: GullWing
T1 = ZMPT101B: Current-type Voltage Transformer; MF: Qingxian Zeming Langxi Electronic; Availability: Not in stock; Check_prices: https://www.snapeda.com/parts/ZMPT101B/Qingxian+Zeming+Langxi+Electronic/view-part/?ref=eda; Component Kind: Standard; Library Name: ZMPT101B.SchLib; MP: ZMPT101B; Package: None; Price: None; SnapEDA_Link: https://www.snapeda.com/parts/ZMPT101B/Qingxian+Zeming+Langxi+Electronic/view-part/?ref=snap
U1 U3 = LD1117: IC REG LINEAR 3.3V 800MA SOT223; Accuracy: 1%; Dropout Voltage: 1.1V; Input Bias Current: 5mA; Max Input Voltage: 15V; Max Output Current: 1.3A; Max Power Dissipation: 12W; Min Input Voltage: 5.3V; Number of Outputs: 1; Output Current: 950mA; Output Type: Fixed; Polarity: Positive; Quiescent Current: 10mA; Case/Package: SOT-223; Component Kind: Standard; Contact Plating: Tin; Height: 1.8mm; Lead Free: Lead Free; Length: 6.5mm; Library Name: CustomLib-Package.IntLib; Max Operating Temperature: 125°C; Max Output Voltage: 3.3V; Max Supply Voltage: 15V; Min Operating Temperature: -40°C; Min Output Voltage: 3.3V; Min Supply Voltage: 3.3V; Mount: Surface Mount; Nominal Output Voltage: 3.3V; Number of Pins: 4; Number of Regulators: 1; Number of Terminals: 4; Output Voltage: 3.3V; Output Voltage Accuracy: 1%; Package Quantity: 1; Packaging: Tape and Reel; Power Dissipation: 12W; Radiation Hardening: No; REACH SVHC: No SVHC; Termination: SMD/SMT; Weight: 0.01lb; Width: 3.5mm
U2 = CMP-82923-000001-3: SENSOR CURRENT HALL 20A AC/DC; Accuracy: 0.8%; Bandwidth: 120kHz; Linearity: 1.5%; Max Supply Current: 14mA; Number of Channels: 1; Polarity: Bidirectional; Quiescent Current: 10mA; Response Time: 4us; Sensitivity: 66mV/A; Case/Package: SOIC; Component Kind: Standard; Current Sensing: 20A; Frequency: 120kHz; Library Name: Altium Content Vault; Max Operating Temperature: 150°C; Min Operating Temperature: -40°C; Mount: Surface Mount; Number of Pins: 8; Packaging: Tape and Reel; RoHS Compliant: Yes
U4 = CMP-1310-00289-1: Single Supply Dual Operational Amplifier, 3 to 32 V, 0 to 70 degC, 8-Pin SOIC, Pb-Free, Tape and Reel; Manufacturer: On Semiconductor; VCC Max (V): 32; VCC Min (V): 3; Component Kind: Standard; ComponentLink1Description: Manufacturer URL; ComponentLink1URL: http://www.onsemi.com/; ComponentLink2Description: Datasheet; ComponentLink2URL: http://www.onsemi.cn/pub_link/Collateral/LM358-D.PDF; ComponentLink3Description: Package Specification; ComponentLink3URL: http://www.onsemi.com/pub_link/Collateral/751-07.PDF; DatasheetVersion: Rev. 27; Library Name: Altium Content Vault; Mounting Technology: Surface Mount; PackageDescription: 8-Pin Small Outline Integrated Circuit, Body 4.9 x 3.9 mm, Pitch 1.27 mm; PackageReference: SOIC-8-751-07; PackageVersion: Rev. AK, 02/2011; Packing: Tape and Reel; PartNumber: LM358ADR2G; RoHS: TRUE
U5 = ESP32-S3-WROOM-1U-N16R8: Bluetooth, WiFi 802.11b/g/n, Bluetooth v5.0 Transceiver Module 2.4GHz Antenna Not Included, U.FL Surface Mount; MF: Espressif Systems; Availability: Unavailable; Check_prices: https://www.snapeda.com/parts/ESP32-S3-WROOM-1U-N16R8/Espressif+Systems/view-part/?ref=eda; Component Kind: Standard; Library Name: ESP32-S3-WROOM-1U-N16R8.SchLib; MP: ESP32-S3-WROOM-1U-N16R8; Package: None; Price: None; SnapEDA_Link: https://www.snapeda.com/parts/ESP32-S3-WROOM-1U-N16R8/Espressif+Systems/view-part/?ref=snap
U6 = CMP-0511-00012-1: Single-Chip USB to UART Bridge, 1024 Bytes EEPROM, -40 to 85 degC, 28-pin QFN, Tube; Manufacturer: Silicon Labs; Internal Oscillator: TRUE; Sub-Family: USB Bridges; Code_IPC: J-STD-020C; Code_JEDEC: MO-220; Component Kind: Standard; ComponentLink1Description: Manufacturer URL; ComponentLink1URL: http://www.silabs.com/; ComponentLink2Description: Datasheet; ComponentLink2URL: http://www.silabs.com/Support%20Documents/TechnicalDocs/CP2102-9.pdf; ComponentLink3Description: Package Specification; ComponentLink3URL: http://www.silabs.com/Support%20Documents/TechnicalDocs/C8051T61x.pdf; DatasheetVersion: Rev. 1.5; EEPROM: 1024 Bytes; Library Name: Altium Content Vault; Mounting Technology: Surface Mount; PackageDescription: 28-Pin Quad-Flat No-Leads Package, Body 5 x 5 mm, Pitch 0.5 mm; PackageReference: QFN-28; PartNumber: CP2102-GM
